# Altium schematic sheet IO.SchDoc(IO)
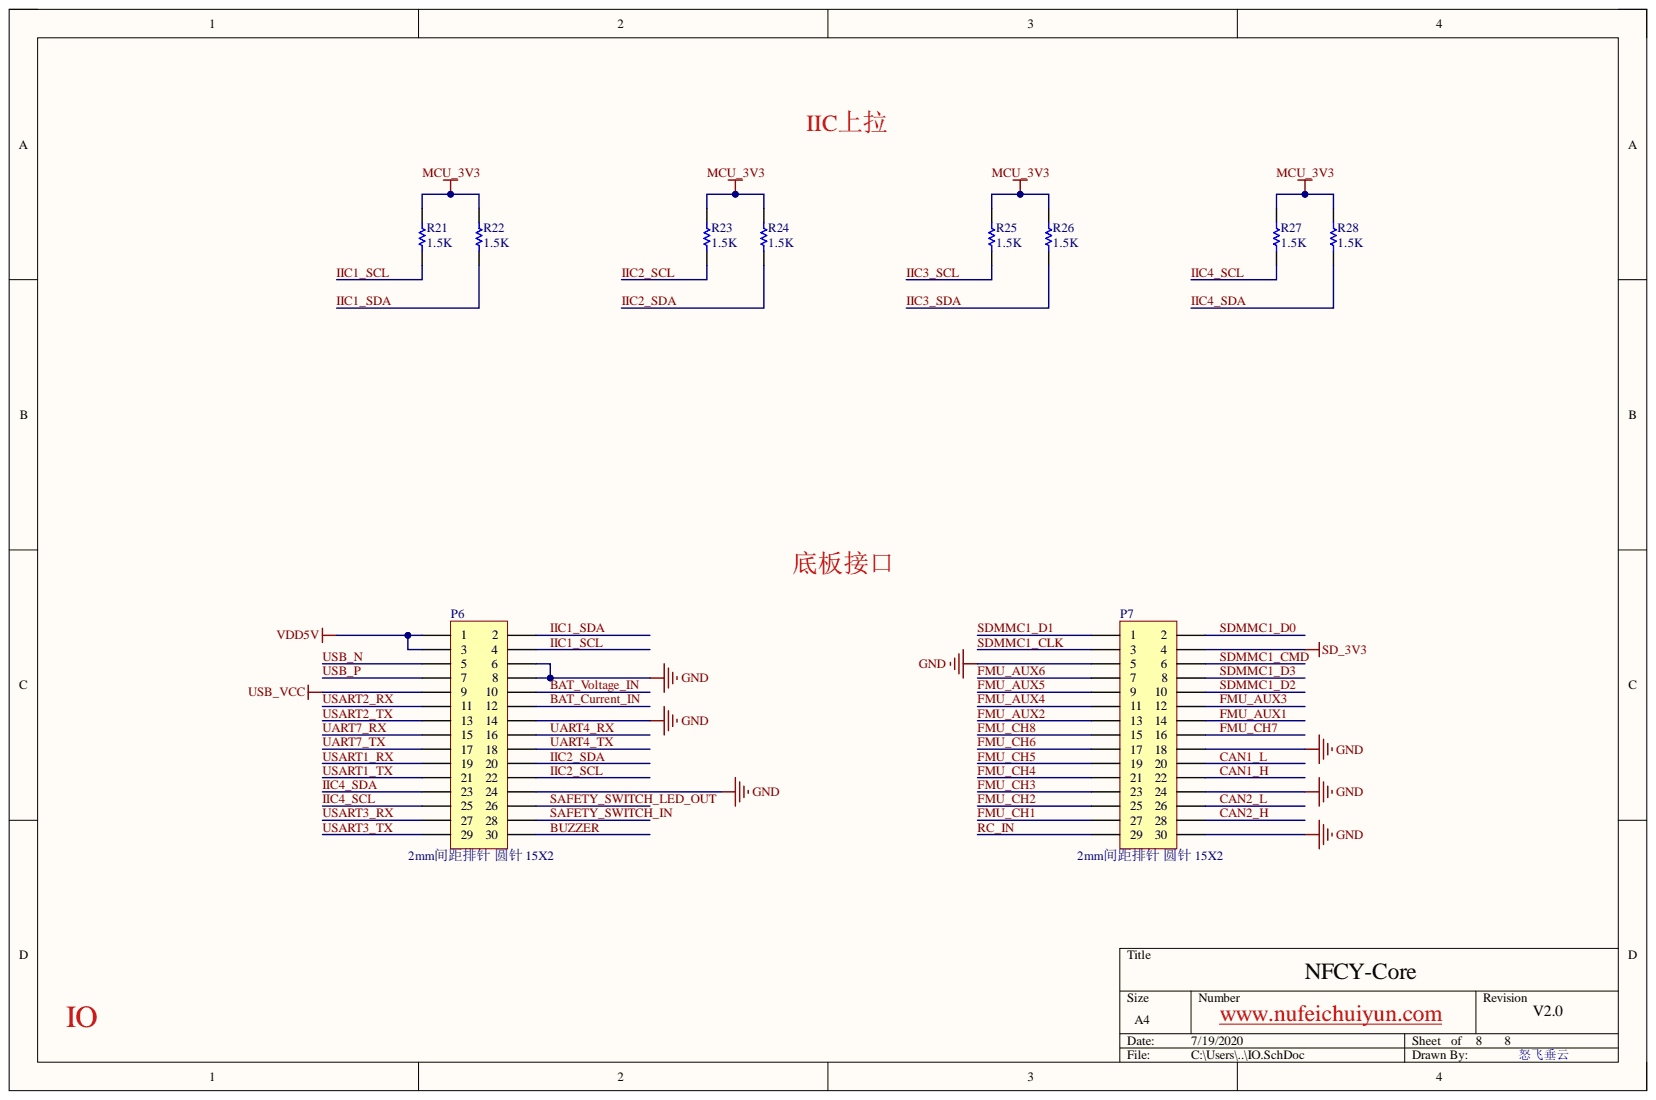
[diagram p0: IO.SchDoc]

## Parts
P6  2mm间距排针 圆针 15X2  [HDR_C15X2_2mm]
P7  2mm间距排针 圆针 15X2  [HDR_C15X2_2mm]
R21  value 1.5K  [RES0402]  (hidden comment: RC0402FR-071K5L)
R22  value 1.5K  [RES0402]  (hidden comment: RC0402FR-071K5L)
R23  value 1.5K  [RES0402]  (hidden comment: RC0402FR-071K5L)
R24  value 1.5K  [RES0402]  (hidden comment: RC0402FR-071K5L)
R25  value 1.5K  [RES0402]  (hidden comment: RC0402FR-071K5L)
R26  value 1.5K  [RES0402]  (hidden comment: RC0402FR-071K5L)
R27  value 1.5K  [RES0402]  (hidden comment: RC0402FR-071K5L)
R28  value 1.5K  [RES0402]  (hidden comment: RC0402FR-071K5L)

## Nets
GND: P6.6 P6.8 P6.14 P6.24 P7.5 P7.18 P7.24 P7.30
MCU_3V3: R21.1 R22.1 R23.1 R24.1 R25.1 R26.1 R27.1 R28.1
SD_3V3: P7.4
USB_VCC: P6.9
VDD5V: P6.1 P6.3
BAT_Current_IN: P6.12
BAT_Voltage_IN: P6.10
BUZZER: P6.30
CAN1_H: P7.22
CAN1_L: P7.20
CAN2_H: P7.28
CAN2_L: P7.26
FMU_AUX1: P7.14
FMU_AUX2: P7.13
FMU_AUX3: P7.12
FMU_AUX4: P7.11
FMU_AUX5: P7.9
FMU_AUX6: P7.7
FMU_CH1: P7.27
FMU_CH2: P7.25
FMU_CH3: P7.23
FMU_CH4: P7.21
FMU_CH5: P7.19
FMU_CH6: P7.17
FMU_CH7: P7.16
FMU_CH8: P7.15
IIC1_SCL: P6.4 R21.2
IIC1_SDA: P6.2 R22.2
IIC2_SCL: P6.22 R23.2
IIC2_SDA: P6.20 R24.2
IIC3_SCL: R25.2
IIC3_SDA: R26.2
IIC4_SCL: P6.25 R27.2
IIC4_SDA: P6.23 R28.2
RC_IN: P7.29
SAFETY_SWITCH_IN: P6.28
SAFETY_SWITCH_LED_OUT: P6.26
SDMMC1_CLK: P7.3
SDMMC1_CMD: P7.6
SDMMC1_D0: P7.2
SDMMC1_D1: P7.1
SDMMC1_D2: P7.10
SDMMC1_D3: P7.8
UART4_RX: P6.16
UART4_TX: P6.18
UART7_RX: P6.15
UART7_TX: P6.17
USART1_RX: P6.19
USART1_TX: P6.21
USART2_RX: P6.11
USART2_TX: P6.13
USART3_RX: P6.27
USART3_TX: P6.29
USB_N: P6.5
USB_P: P6.7

## Library data of the parts
P6 P7 = Header 15X2: 2mm间距排针; Component Kind: Standard; LatestRevisionDate: 17-Jul-2002; LatestRevisionNote: Re-released for DXP Platform.; Library Name: Miscellaneous Connectors.IntLib; Signal Integrity: Connector; Publisher: Altium Limited
R21-R28 = Res1: 贴片电阻; manufacturer: YAGEO(国巨); Component Kind: Standard; LatestRevisionDate: 17-Jul-2002; LatestRevisionNote: Re-released for DXP Platform.; Library Name: Miscellaneous Devices.IntLib; PackageDescription: Axial Device, Thru-Hole; 2 Leads; 0.3 in Pin Spacing; PackageReference: AXIAL-0.3; Published: 8-Jun-2000; Signal Integrity: Res; Simulation: RESISTOR; Publisher: Altium Limited
